# Altium schematic sheet 05 - ETHERNET, USB.SchDoc("05 - ETHERNET, USB")
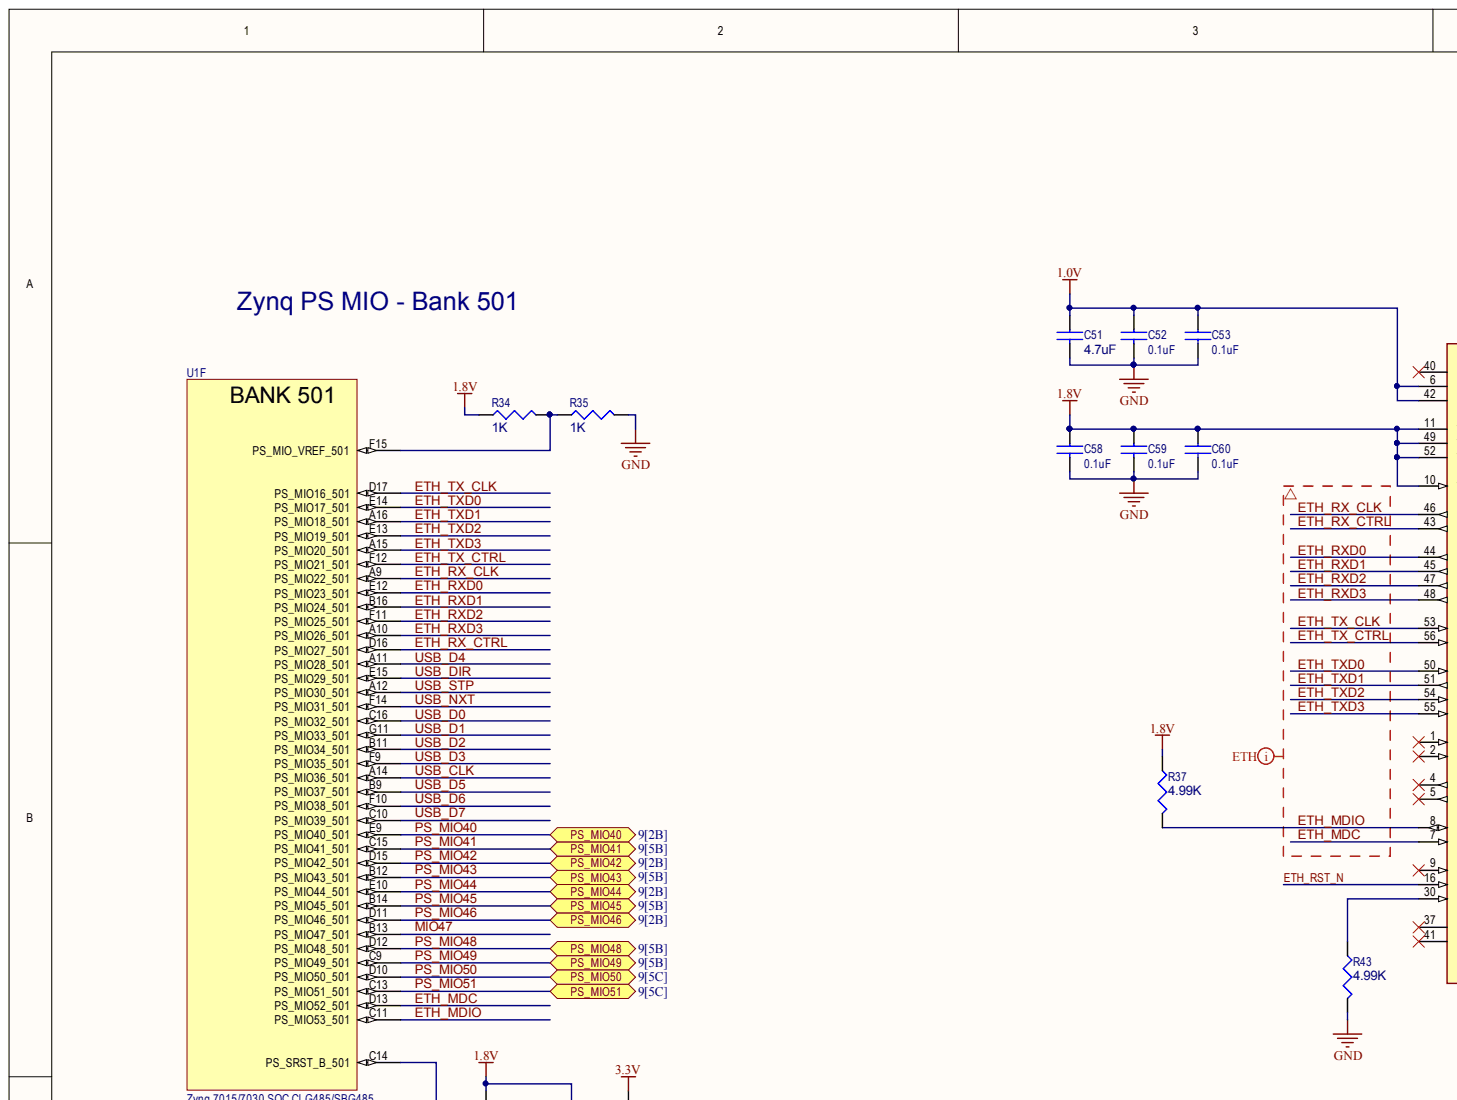
[diagram p0: 05 - ETHERNET, USB.SchDoc, top left]
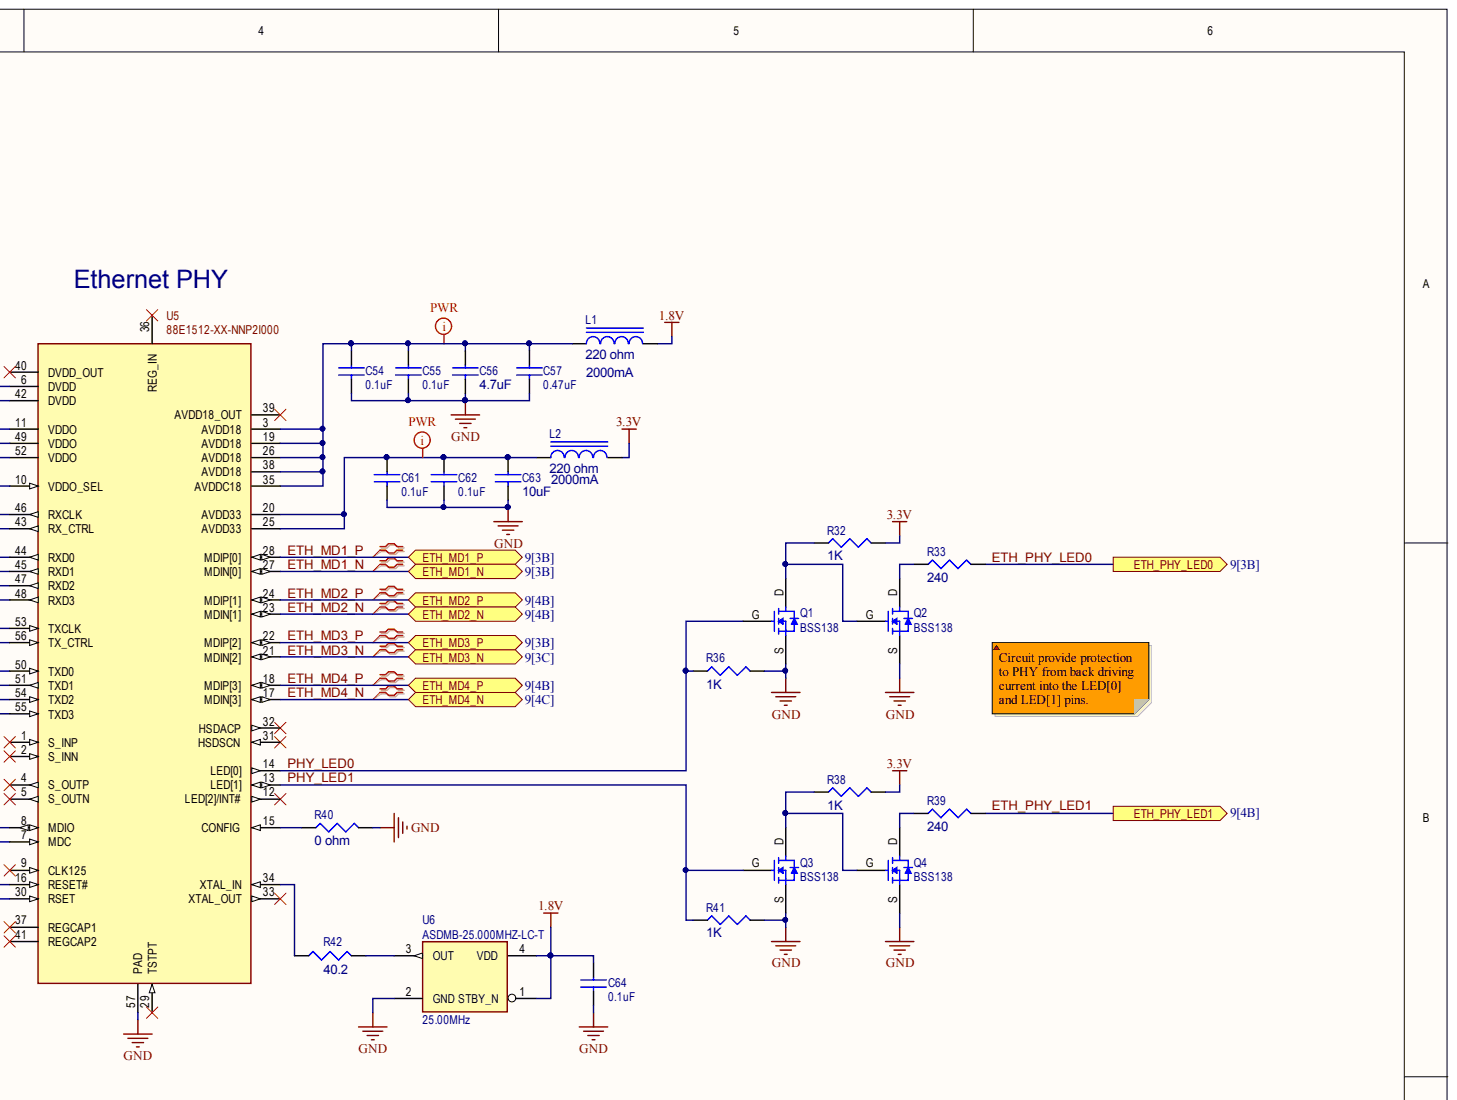
[diagram p1: 05 - ETHERNET, USB.SchDoc, top right]
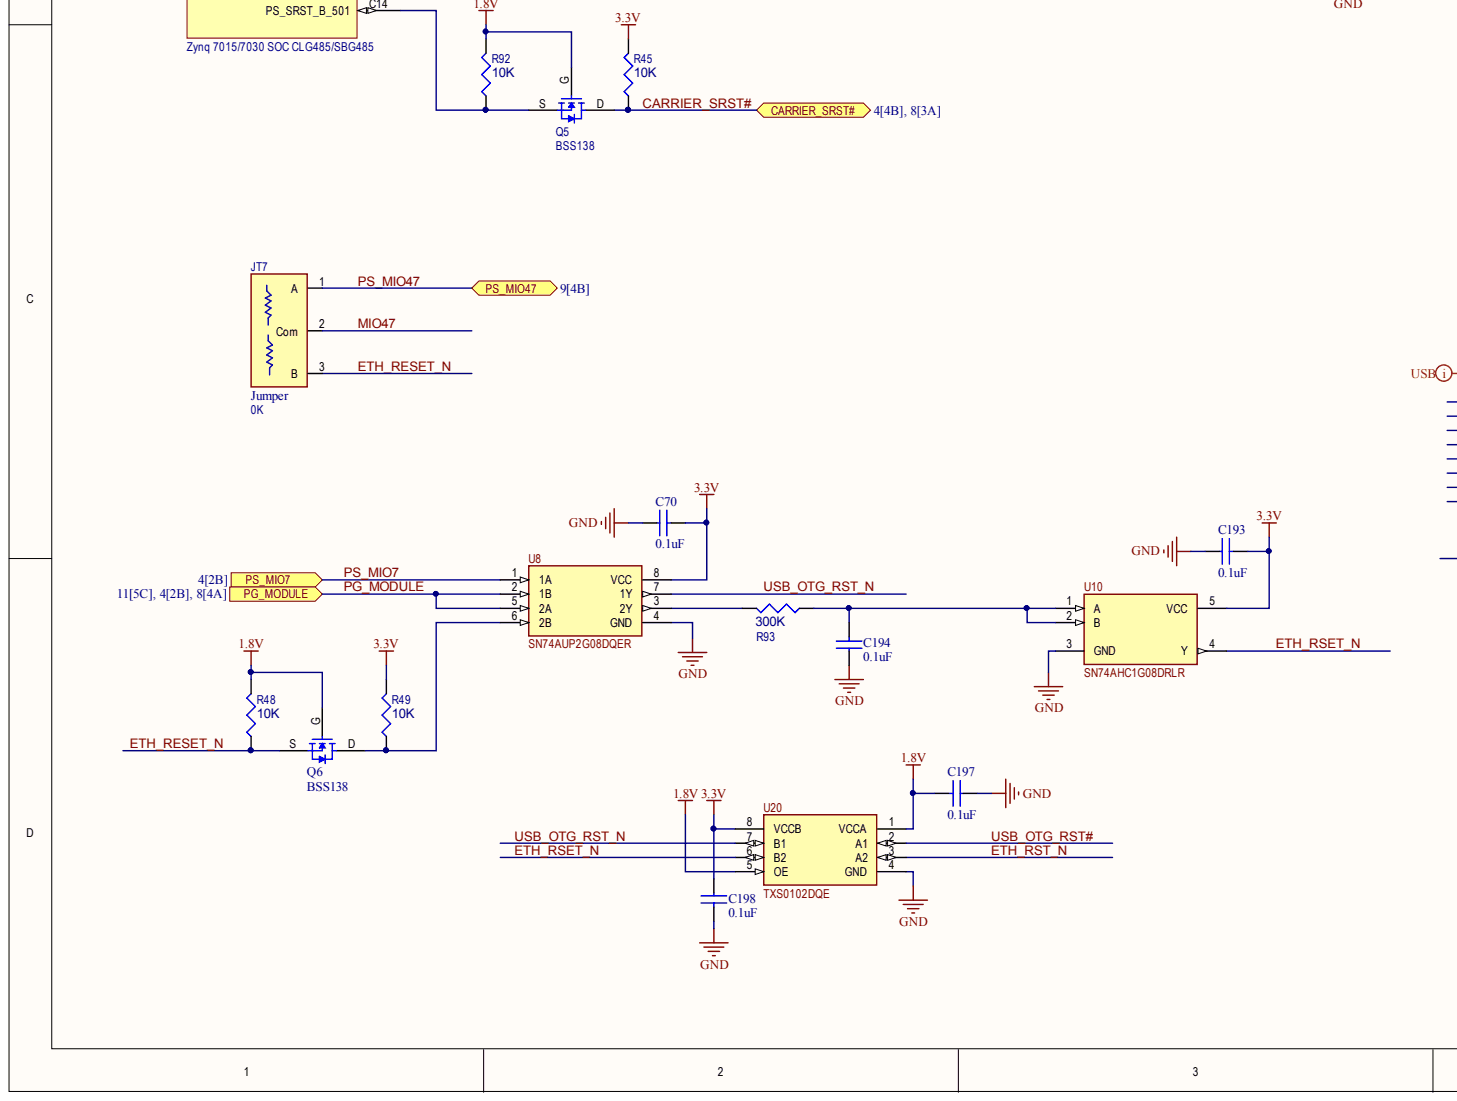
[diagram p2: 05 - ETHERNET, USB.SchDoc, bottom left]
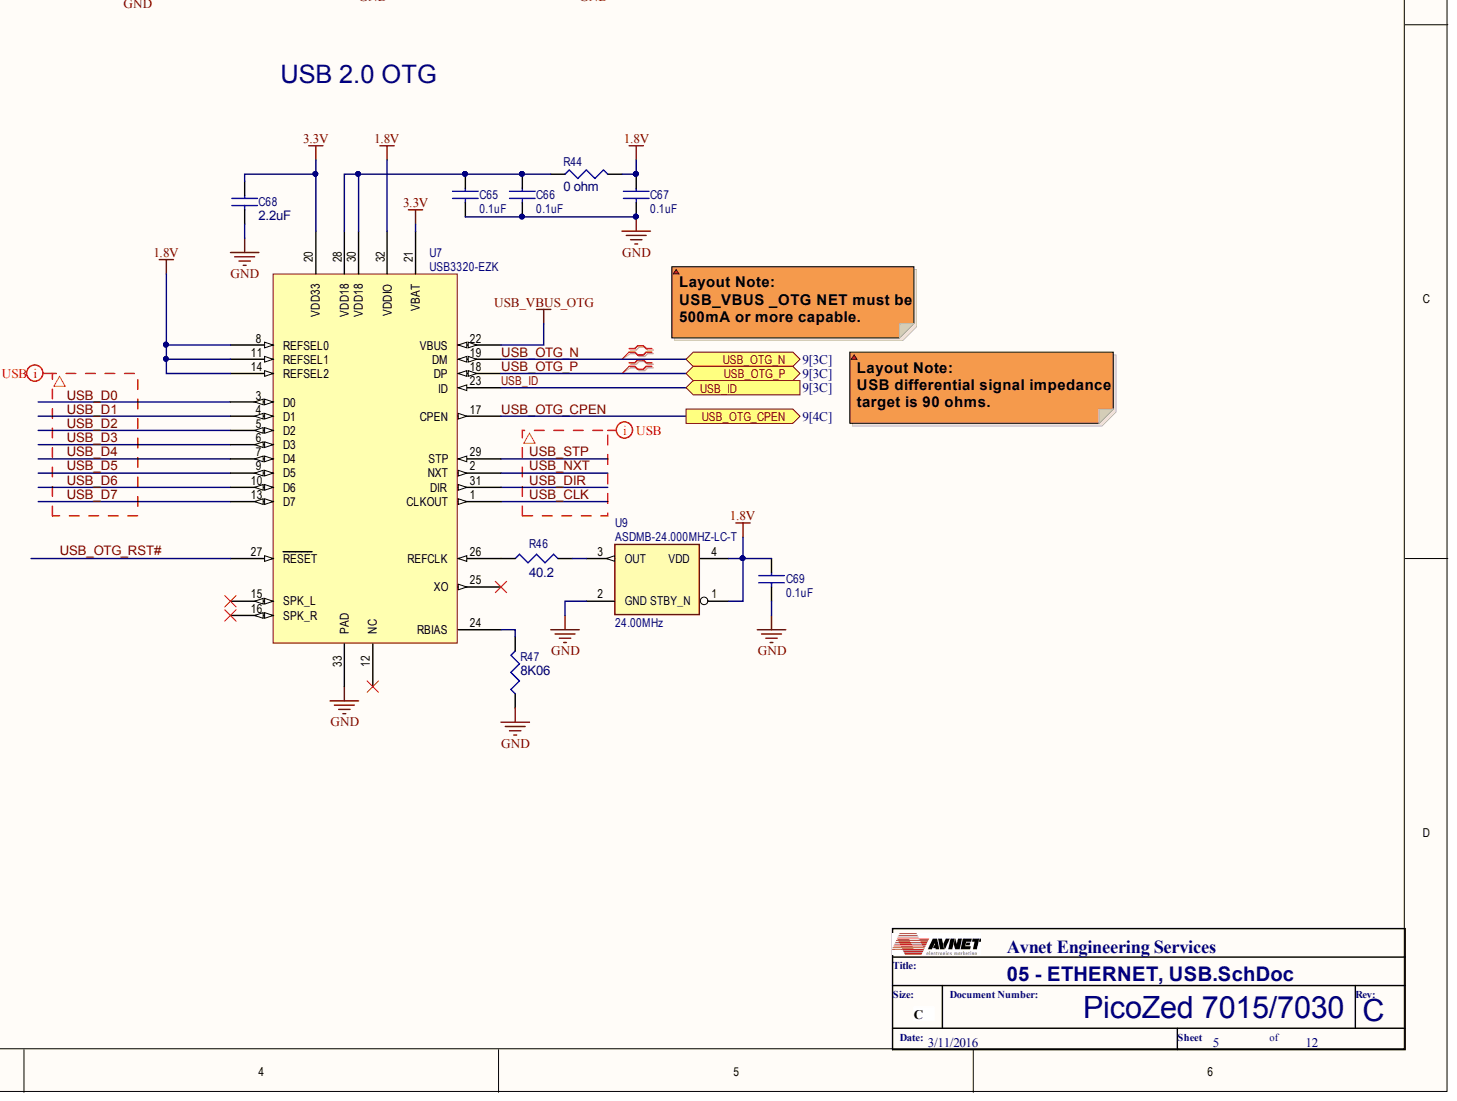
[diagram p3: 05 - ETHERNET, USB.SchDoc, bottom right]

## Parts
C51  4.7uF  [C0402]
C52  value 0.1uF  [C0201]  (hidden comment: PC522)
C53  value 0.1uF  [C0201]  (hidden comment: PC522)
C54  value 0.1uF  [C0201]  (hidden comment: PC522)
C55  value 0.1uF  [C0201]  (hidden comment: PC522)
C56  4.7uF  [C0402]
C57  value 0.47uF  [C0402]  (hidden comment: PC475)
C58  value 0.1uF  [C0201]  (hidden comment: PC522)
C59  value 0.1uF  [C0201]  (hidden comment: PC522)
C60  value 0.1uF  [C0201]  (hidden comment: PC522)
C61  value 0.1uF  [C0201]  (hidden comment: PC522)
C62  value 0.1uF  [C0201]  (hidden comment: PC522)
C63  10uF  [C0603]
C64  value 0.1uF  [C0201]  (hidden comment: PC522)
C65  value 0.1uF  [C0201]  (hidden comment: PC522)
C66  value 0.1uF  [C0201]  (hidden comment: PC522)
C67  value 0.1uF  [C0201]  (hidden comment: PC522)
C68  2.2uF  [C0603]
C69  value 0.1uF  [C0201]  (hidden comment: PC522)
C70  value 0.1uF  [C0201]  (hidden comment: PC522)
C193  value 0.1uF  [C0201]  (hidden comment: PC522)
C194  value 0.1uF  [C0201]  (hidden comment: PC522)
C197  value 0.1uF  [C0201]  (hidden comment: PC522)
C198  value 0.1uF  [C0201]  (hidden comment: PC522)
JT7  value 0K  Device: Jumper  [R0402-DUAL_JMP]
L1  value 220 ohm  Imax: 2000mA  [L0805]  (hidden comment: PF009)
L2  value 220 ohm  Imax: 2000mA  [L0805]  (hidden comment: PF009)
Q1  BSS138  [SOT23GSD]
Q2  BSS138  [SOT23GSD]
Q3  BSS138  [SOT23GSD]
Q4  BSS138  [SOT23GSD]
Q5  BSS138  [SOT23GSD]
Q6  BSS138  [SOT23GSD]
R32  1K  [R0402]
R33  240  [R0402]
R34  1K  [R0402]
R35  1K  [R0402]
R36  1K  [R0402]
R37  4.99K  [R0402]
R38  1K  [R0402]
R39  240  [R0402]
R40  0 ohm  [R0402]
R41  1K  [R0402]
R42  40.2  [R0402]
R43  4.99K  [R0402]
R44  0 ohm  [R0402]
R45  10K  [R0402]
R46  40.2  [R0402]
R47  8K06  [R0402]
R48  10K  [R0402]
R49  10K  [R0402]
R92  10K  [R0402]
R93  300K  [R0402]
U1  Zynq 7015/7030 SOC CLG485/SBG485  [BGA81C80P22X22_1900X1900X244]  (part F)
U5  88E1512-XX-NNP2I000  [QFN56_14X14]
U6  25.00MHz  MFGPN: ASDMB-25.000MHZ-LC-T  [XTL_DSC1001-2.5X2.0]
U7  USB3320-EZK  [QFN32]
U8  SN74AUP2G08DQER  [DQE8]
U9  24.00MHz  MFGPN: ASDMB-24.000MHZ-LC-T  [XTL_DSC1001-2.5X2.0]
U10  SN74AHC1G08DRLR  [SOT553]
U20  TXS0102DQE  [DQE8]

## Ports
CARRIER_SRST#, ETH_MD1_N, ETH_MD1_P, ETH_MD2_N, ETH_MD2_P, ETH_MD3_N, ETH_MD3_P, ETH_MD4_N, ETH_MD4_P, ETH_PHY_LED0, ETH_PHY_LED1, PG_MODULE, PS_MIO7, PS_MIO40, PS_MIO41, PS_MIO42, PS_MIO43, PS_MIO44, PS_MIO45, PS_MIO46, PS_MIO47, PS_MIO48, PS_MIO49, PS_MIO50, PS_MIO51, USB_ID, USB_OTG_CPEN, USB_OTG_N, USB_OTG_P

## Nets
1.0V: C51.1 C52.1 C53.1 U5.6(DVDD) U5.42(DVDD)
1.8V: C58.1 C59.1 C60.1 C64.1 C67.1 C69.1 C197.2 L1.2 Q5.G Q6.G R34.2 R37.1 R44.2 R48.2 R92.2 U5.10(VDDO_SEL) U5.11(VDDO) U5.49(VDDO) U5.52(VDDO) U6.1(STBY_N) U6.4(VDD) U7.8(REFSEL0) U7.11(REFSEL1) U7.14(REFSEL2) U7.32(VDDIO) U9.1(STBY_N) U9.4(VDD) U20.1(VCCA) U20.5(OE)
3.3V: C68.1 C70.2 C193.2 C198.1 L2.2 R32.1 R38.1 R45.2 R49.2 U7.20(VDD33) U7.21(VBAT) U8.8(VCC) U10.5(VCC) U20.8(VCCB)
GND: C51.2 C52.2 C53.2 C54.2 C55.2 C56.2 C57.2 C58.2 C59.2 C60.2 C61.2 C62.2 C63.2 C64.2 C65.2 C66.2 C67.2 C68.2 C69.2 C70.1 C193.1 C194.1 C197.1 C198.2 Q1.S Q2.S Q3.S Q4.S R35.1 R36.1 R40.1 R41.1 R43.2 R47.1 U5.57(PAD) U6.2(GND) U7.33(PAD) U8.4(GND) U9.2(GND) U10.3(GND) U20.4(GND)
USB_VBUS_OTG: U7.22(VBUS)
CARRIER_SRST#: Q5.D R45.1 ; port CARRIER_SRST#
ETH_MD1_N: U5.27(MDIN[0]) ; port ETH_MD1_N
ETH_MD1_P: U5.28(MDIP[0]) ; port ETH_MD1_P
ETH_MD2_N: U5.23(MDIN[1]) ; port ETH_MD2_N
ETH_MD2_P: U5.24(MDIP[1]) ; port ETH_MD2_P
ETH_MD3_N: U5.21(MDIN[2]) ; port ETH_MD3_N
ETH_MD3_P: U5.22(MDIP[2]) ; port ETH_MD3_P
ETH_MD4_N: U5.17(MDIN[3]) ; port ETH_MD4_N
ETH_MD4_P: U5.18(MDIP[3]) ; port ETH_MD4_P
ETH_MDC: U1.D13(PS_MIO52_501) U5.7(MDC)
ETH_MDIO: R37.2 U1.C11(PS_MIO53_501) U5.8(MDIO)
ETH_PHY_LED0: R33.2 ; port ETH_PHY_LED0
ETH_PHY_LED1: R39.2 ; port ETH_PHY_LED1
ETH_RESET_N: JT7.3 Q6.S R48.1
ETH_RSET_N: U10.4(Y) U20.6(B2)
ETH_RST_N: U5.16(RESET#) U20.3(A2)
ETH_RX_CLK: U1.A9(PS_MIO22_501) U5.46(RXCLK)
ETH_RX_CTRL: U1.D16(PS_MIO27_501) U5.43(RX_CTRL)
ETH_RXD0: U1.E12(PS_MIO23_501) U5.44(RXD0)
ETH_RXD1: U1.B16(PS_MIO24_501) U5.45(RXD1)
ETH_RXD2: U1.F11(PS_MIO25_501) U5.47(RXD2)
ETH_RXD3: U1.A10(PS_MIO26_501) U5.48(RXD3)
ETH_TX_CLK: U1.D17(PS_MIO16_501) U5.53(TXCLK)
ETH_TX_CTRL: U1.F12(PS_MIO21_501) U5.56(TX_CTRL)
ETH_TXD0: U1.E14(PS_MIO17_501) U5.50(TXD0)
ETH_TXD1: U1.A16(PS_MIO18_501) U5.51(TXD1)
ETH_TXD2: U1.E13(PS_MIO19_501) U5.54(TXD2)
ETH_TXD3: U1.A15(PS_MIO20_501) U5.55(TXD3)
MIO47: JT7.2 U1.B13(PS_MIO47_501)
PG_MODULE: U8.2(1B) U8.5(2A) ; port PG_MODULE
PHY_LED0: Q1.G R36.2 U5.14(LED[0])
PHY_LED1: Q3.G R41.2 U5.13(LED[1])
PS_MIO7: U8.1(1A) ; port PS_MIO7
PS_MIO40: U1.E9(PS_MIO40_501) ; port PS_MIO40
PS_MIO41: U1.C15(PS_MIO41_501) ; port PS_MIO41
PS_MIO42: U1.D15(PS_MIO42_501) ; port PS_MIO42
PS_MIO43: U1.B12(PS_MIO43_501) ; port PS_MIO43
PS_MIO44: U1.E10(PS_MIO44_501) ; port PS_MIO44
PS_MIO45: U1.B14(PS_MIO45_501) ; port PS_MIO45
PS_MIO46: U1.D11(PS_MIO46_501) ; port PS_MIO46
PS_MIO47: JT7.1 ; port PS_MIO47
PS_MIO48: U1.D12(PS_MIO48_501) ; port PS_MIO48
PS_MIO49: U1.C9(PS_MIO49_501) ; port PS_MIO49
PS_MIO50: U1.D10(PS_MIO50_501) ; port PS_MIO50
PS_MIO51: U1.C13(PS_MIO51_501) ; port PS_MIO51
USB_CLK: U1.A14(PS_MIO36_501) U7.1(CLKOUT)
USB_D0: U1.C16(PS_MIO32_501) U7.3(D0)
USB_D1: U1.G11(PS_MIO33_501) U7.4(D1)
USB_D2: U1.B11(PS_MIO34_501) U7.5(D2)
USB_D3: U1.F9(PS_MIO35_501) U7.6(D3)
USB_D4: U1.A11(PS_MIO28_501) U7.7(D4)
USB_D5: U1.B9(PS_MIO37_501) U7.9(D5)
USB_D6: U1.F10(PS_MIO38_501) U7.10(D6)
USB_D7: U1.C10(PS_MIO39_501) U7.13(D7)
USB_DIR: U1.E15(PS_MIO29_501) U7.31(DIR)
USB_ID: U7.23(ID) ; port USB_ID
USB_NXT: U1.F14(PS_MIO31_501) U7.2(NXT)
USB_OTG_CPEN: U7.17(CPEN) ; port USB_OTG_CPEN
USB_OTG_N: U7.19(DM) ; port USB_OTG_N
USB_OTG_P: U7.18(DP) ; port USB_OTG_P
USB_OTG_RST#: U7.27(RESET) U20.2(A1)
USB_OTG_RST_N: U8.7(1Y) U20.7(B1)
USB_STP: U1.A12(PS_MIO30_501) U7.29(STP)
NetC54_1: C54.1 C55.1 C56.1 C57.1 L1.1 U5.3(AVDD18) U5.19(AVDD18) U5.26(AVDD18) U5.35(AVDDC18) U5.38(AVDD18)
NetC61_1: C61.1 C62.1 C63.1 L2.1 U5.20(AVDD33) U5.25(AVDD33)
NetC65_1: C65.1 C66.1 R44.1 U7.28(VDD18) U7.30(VDD18)
NetC194_2: C194.2 R93.1 U10.1(A) U10.2(B)
NetQ1_D: Q1.D Q2.G R32.2
NetQ2_D: Q2.D R33.1
NetQ3_D: Q3.D Q4.G R38.2
NetQ4_D: Q4.D R39.1
NetQ5_S: Q5.S R92.1 U1.C14(PS_SRST_B_501)
NetQ6_D: Q6.D R49.1 U8.6(2B)
NetR34_1: R34.1 R35.2 U1.F15(PS_MIO_VREF_501)
NetR40_2: R40.2 U5.15(CONFIG)
NetR42_1: R42.1 U5.34(XTAL_IN)
NetR42_2: R42.2 U6.3(OUT)
NetR43_1: R43.1 U5.30(RSET)
NetR46_1: R46.1 U7.26(REFCLK)
NetR46_2: R46.2 U9.3(OUT)
NetR47_2: R47.2 U7.24(RBIAS)
NetR93_2: R93.2 U8.3(2Y)
N00242:
N00243:
N00244:

Not connected: U5 1(S_INP) 2(S_INN) 4(S_OUTP) 5(S_OUTN) 9(CLK125) 12(LED[2]/INT#) 29(TSTPT) 31(HSDSCN) 32(HSDACP) 33(XTAL_OUT) 36(REG_IN) 37(REGCAP1) 39(AVDD18_OUT) 40(DVDD_OUT) 41(REGCAP2); U7 12(NC) 15(SPK_L) 16(SPK_R) 25(XO)

## Library data of the parts
C51 C56 = AVPC-0000499: CAP SM 4.7uF 6.3V 20% X5R C0402; PartCode: PC; AsyTxt: PC499; Database Table Name: Passive-Cap$; MFG: Murata; MFG Code: AVX; MFGPN: GRM155R60J475ME87D; Power: 0; Temp: I; Availability: 140K-DK; AVLNo: AVPC-0000499; CLASSNAME: U11_BYP; Component Kind: Standard; ComponentLink1Description: Datasheet; Cost: .46; Device: CAP; Dielectric: X5R; PkgType: SM; Received: 0; RoHS: Yes; Size: 1.9; Status: Preliminary; Tol: 20%; Value: 4.7uF; Volt: 6.3V; ComponentLink1URL: C:\AVDBLIB\DATASHEETS\murata_caps_c02e.pdf; Library Name: Avnet_DBlib.DbLib
C52-C55 C58-C62 C64-C67 C69 = AVPC-0000522: CAP SM 0.1uF 16V 10% X5R C0201; PartCode: PC; Database Table Name: Passive-Cap$; MFG: TDK; MFGPN: C0603X5R1C104K030BC; Temp: I; AsyTxt: PC522; Component Kind: Standard; ComponentLink1Description: Datasheet; Device: CAP; Dielectric: X5R; MFG Code: TDK; PkgType: SM; RoHS: Yes; Status: Preliminary; Tol: 10%; Volt: 16V; Library Name: Avnet_DBlib.DbLib
  C52-C55 C58-C62: CLASSNAME: U11_BYP
C57 = AVPC-0000475: CAP SM 0.47uF 6.3V 10% X5R C0402; PartCode: PC; AVLNo: AVPC-0000529; Database Table Name: Passive-Cap$; MFG: Taiyo Yuden; MFG Code: TAI; MFGPN: JMK105BJ474KV-F; Temp: I; AsyTxt: PC475; CLASSNAME: U11_BYP; Component Kind: Standard; ComponentLink1Description: Datasheet; ComponentLink2Description: MFR Website; Device: CAP; Dielectric: X5R; ESR: CAP; PkgType: SM; RoHS: Yes; Status: Preliminary; Tol: 10%; Volt: 6.3V; ComponentLink1URL: C:\AVDBLIB\DATASHEETS\; Library Name: Avnet_DBlib.DbLib
C63 = AVPC-0000086: CAP SM 10uF 6.3V 20% X5R C0603; PartCode: PC; AsyTxt: PC086; Database Table Name: Passive-Cap$; MFG: AVX; MFGPN: 06036D106MAT2A; Temp: I; AVLNo: AVPC-0000086; CLASSNAME: U11_BYP; Component Kind: Standard; ComponentLink1Description: Datasheet; Device: CAP; Dielectric: X5R; ESR: CAP; MFG Code: AVX; PkgType: SM; RoHS: Yes; Status: Preliminary; Tol: 20%; Value: 10uF; Volt: 6.3V; ComponentLink1URL: C:\AVDBLIB\DATASHEETS\AVX_CCOG.pdf; Library Name: Avnet_DBlib.DbLib
C68 = AVPC-0000155: CAP SM 2.2uF 6.3V 10% X5R C0603; PartCode: PC; AsyTxt: PC155; Database Table Name: Passive-Cap$; MFG: AVX; MFGPN: 06036D225KAT2A; Power: 0; Temp: I; Availability: 4K-AV; AVLNo: AVPC-0000155; Component Kind: Standard; ComponentLink1Description: Datasheet; ComponentLink2Description: MFR Website; ComponentLink2URL: www.avx.com; Cost: 0.04; Device: CAP; Dielectric: X5R; MFG Code: AVX; PkgType: SM; Received: 0; RoHS: Yes; Size: 3.4; Status: Preliminary; Tol: 10%; Value: 2.2uF; Volt: 6.3V; ComponentLink1URL: C:\AVDBLIB\DATASHEETS\AVX_CX5R.pdf; Library Name: Avnet_DBlib.DbLib
C70 C194 = AVPC-0000522: CAP SM 0.1uF 10V 10% X5R C0201; PartCode: PC; Database Table Name: Passive-Cap$; MFG: AVX; MFGPN: 02016D104KAT2A; Temp: I; AsyTxt: PC522; AVLNo: AVPC-0000522; Component Kind: Standard; ComponentLink1Description: Datasheet; Device: CAP; Dielectric: X5R; PkgType: SM; RoHS: Yes; Status: Preliminary; Tol: 10%; Volt: 10V; ComponentLink1URL: C:\AVDBLIB\DATASHEETS\AVX_CCOG.pdf; Library Name: Avnet_DBlib.DbLib
C193 C197 C198 = AVPC-0000522: CAP SM 0.1uF 10V 10% X5R C0201; PartCode: PC; Database Table Name: Passive-Cap$; MFG Code: AVX; MFGPN: 02016D104KAT2A; AsyTxt: PC522; AVLNo: AVPC-0000522; Component Kind: Standard; ComponentLink1Description: Datasheet; Device: CAP; Dielectric: X5R; PkgType: SM; RoHS: Yes; Status: Preliminary; Tol: 10%; Volt: 10V; ComponentLink1URL: C:\AVDBLIB\DATASHEETS\AVX_CCOG.pdf; Library Name: Avnet_DBlib.DbLib
JT7 = AVPO-0000025: Jumper 3-pad 0402 RES - 0 Ohm : Pads 1-2; PartCode: PO; Database Table Name: Passive-Other$; Device Type: 3-pad 0R0 0402; MFG: Vishay; MFGPN: CRCW04020000Z0ED; Note: Place Pads: 1-2; PkgType: SM; Temp: I; Component Kind: Standard; ComponentLink1Description: Datasheet; Part #: CRCW04020000Z0ED; RoHS: Yes; Status: Preliminary; ComponentLink1URL: C:\AVDBLIB\DATASHEETS\Dale_CRCW.pd; Library Name: Avnet_DBlib.DbLib
L1 L2 = AVPF-0000009: FB SM 220 ohm@100MHz 2000mA 0.25 0.045 ohm@DC L0805; PartCode: PF; Database Table Name: Passive-Ferrite Bead$; MFG: Murata; MFG Code: MUR; MFGPN: BLM21PG221SN1D; Temp: C; Test Freq: 100MHz; Tol: 0.25; AsyTxt: PF009; AVLNo: AVPF-0000009; CLASSNAME: U11_BYP; Component Kind: Standard; ComponentLink1Description: Datasheet; DCR: 0.045 ohm; Device: FB; PkgType: SM; RoHS: Yes; Status: Preliminary; ComponentLink1URL: C:\AVDBLIB\DATASHEETS\L0110S0100BLM21P.pdf; Library Name: Avnet_DBlib.DbLib
Q1-Q5 = MOSFET-N: MFG: On Semi; MFGPN: BSS138LT1G; Temp: I; Component Kind: Standard; Description: MOSFET-N; Library Name: HKADS_Lib.IntLib
Q6 = MOSFET-N: MFG#1/Part#: OnSemi: BSS138LT1G; MFG Code: ON SEMI; MFGPN: BSS138LT1G; Component Kind: Standard; Description: MOSFET-N; MFG Code: ON Semi; Library Name: HKADS_Lib.IntLib
R32 R34-R36 R38 R41 = AVPR-0000052: RES SM 1K 62mW 0.01 R0402; PartCode: PR; AsyTxt: PR052; Database Table Name: Passive-Res$; MFG: Vishay; MFG Code: DAL; MFGPN: CRCW04021K00FKED; Temp: I; Tol: 0.01; AVLNo: AVPR-0000052; Component Kind: Standard; ComponentLink1Description: Datasheet; Device: RES; PkgType: SM; RoHS: Yes; Status: Preliminary; Value: 1K; Watt: 62mW; ComponentLink1URL: C:\AVDBLIB\DATASHEETS\Dale_CRCW.pd; Library Name: Avnet_DBlib.DbLib
R33 R39 = AVPR-0000511: RES SM 240 62mW 0.01 R0402; Alt1MFR: NIC; PartCode: PR; Alt1PN: NRC04F2400TRF; AsyTxt: PR511; Database Table Name: Passive-Res$; MFG: Vishay; MFG Code: DAL; MFGPN: CRCW0402240RFKED; Power: 0; Temp: I; Tol: 0.01; Availability: 1M-AV; AVLNo: AVPR-0000511; Component Kind: Standard; ComponentLink1Description: Datasheet; Cost: .01; Device: RES; PkgType: SM; Received: 0; RoHS: Yes; Size: 1.9; Status: Preliminary; Value: 240; Watt: 62mW; ComponentLink1URL: C:\AVDBLIB\DATASHEETS\Dale_CRCW.pd; Library Name: Avnet_DBlib.DbLib
R37 R43 = AVPR-0000094: RES SM 4.99K 62mW 0.01 R0402; PartCode: PR; AsyTxt: PR094; Database Table Name: Passive-Res$; MFG: Vishay; MFG Code: DAL; MFGPN: CRCW04024K99FKED; Temp: I; Tol: 0.01; AVLNo: AVPR-0000094; Component Kind: Standard; ComponentLink1Description: Datasheet; Device: RES; PkgType: SM; RoHS: Yes; Status: Preliminary; Value: 4.99K; Watt: 62mW; ComponentLink1URL: C:\AVDBLIB\DATASHEETS\Dale_CRCW.pd; Library Name: Avnet_DBlib.DbLib
R40 R44 = AVPR-0000027: RES SM 0 ohm 62mW J R0402; PartCode: PR; AsyTxt: PR027; Database Table Name: Passive-Res$; MFG: Vishay; MFG Code: DAL; MFGPN: CRCW04020000Z0ED; Temp: I; AVLNo: AVPR-0000027; Component Kind: Standard; ComponentLink1Description: Datasheet; Device: RES; PkgType: SM; RoHS: Yes; Status: Preliminary; Value: 0 ohm; Watt: 62mW; ComponentLink1URL: C:\AVDBLIB\DATASHEETS\Dale_CRCW.pd; Library Name: Avnet_DBlib.DbLib
R42 R46 = (no symbol name): RES SM 40.2 62mW 0.01 R0402; PartCode: PR; AsyTxt: PR092; MFG: Vishay; MFG Code: DAL; MFGPN: CRCW040240R2FKED; Power: 0; Temp: I; Tol: 0.01; Watt: 63mW; Availability: 2M-AV; CLASSNAME: DDR_TERMR; Component Kind: Standard; ComponentLink1Description: Datasheet; Cost: .01; Device: RES; Library Name: Z7045_MM.SchLib; PkgType: SM; Received: 0; RoHS: Yes; Size: 1.9; Status: Preliminary; Value: 40.2; Watt: 62mW; ComponentLink1URL: C:\AVDBLIB\DATASHEETS\Dale_CRCW.pd
R45 R92 = AVPR-0000034: RES SM 10K 62mW 0.01 R0402; PartCode: PR; AsyTxt: PR034; Database Table Name: Passive-Res$; MFG: Vishay; MFG Code: DAL; MFGPN: CRCW040210K0FKED; Power: 0; Temp: I; Tol: 0.01; Watt: 63mW; Availability: 2M-AV; AVLNo: AVPR-0000034; CLASSNAME: DDR_TERM; Component Kind: Standard; ComponentLink1Description: Datasheet; Cost: .01; Device: RES; PkgType: SM; Received: 0; RoHS: Yes; Size: 1.9; Status: Preliminary; Value: 10K; Watt: 62mW; ComponentLink1URL: C:\AVDBLIB\DATASHEETS\Dale_CRCW.pd; Library Name: Avnet_DBlib.DbLib
R47 = (no symbol name): RES SM 8.06k 62mW 0.01 R0402; PartCode: PR; AsyTxt: PR092; AVLNo: AVPR-0000561; MFG: Vishay; MFG Code: DAL; MFGPN: CRCW04028K06FKED; Power: 0; Temp: I; Tol: 0.01; Watt: 63mW; Availability: 2M-AV; Component Kind: Standard; ComponentLink1Description: Datasheet; Cost: .01; Device: RES; Library Name: Z7045_MM.SchLib; PkgType: SM; Received: 0; RoHS: Yes; Size: 1.9; Status: Preliminary; Value: 8K06; Watt: 62mW; ComponentLink1URL: C:\AVDBLIB\DATASHEETS\Dale_CRCW.pd
R48 R49 = AVPR-0000034: RES SM 10.0K 62mW 0.01 R0402; PartCode: PR; AsyTxt: PR034; Database Table Name: Passive-Res$; MFG Code: DAL; MFGPN: CRCW040210K0FKED; Power: 0; Tol: 0.01; Watt: 63mW; Availability: 2M-AV; AVLNo: AVPR-0000034; CLASSNAME: DDR_TERM; Component Kind: Standard; ComponentLink1Description: Datasheet; Cost: .01; Device: RES; PkgType: SM; Received: 0; RoHS: Yes; Size: 1.9; Status: Preliminary; Value: 10K; Watt: 62mW; ComponentLink1URL: C:\AVDBLIB\DATASHEETS\Dale_CRCW.pd; Library Name: Avnet_DBlib.DbLib
R93 = AVPR-0000034: RES SM 300.0K 62mW 0.01 R0402; Database Table Name: Passive-Res$; MFG: Vishay; MFGPN: CRCW0402300KFKED; Temp: I; Watt: 63mW; Component Kind: Standard; Device: RES; Value: 300K; Library Name: Avnet_DBlib.DbLib
U1 = Zynq 7015/7030 SOC SBG485: MFG: Xilinx; MFGPN: XC7Z030-1SBG485-C; Temp: C; CLASSNAME: BGA; Component Kind: Standard; Description: Zynq 7015/7030 SOC SBG485; Library Name: PicoZed+_Rev_A.SCHLIB
U5 = 88E1512: High Integrated 10/100/1000 Ultra Gigabit Ethernet Tranceiver; AVLNo: AVIY-0000047; MFG: Marvell; MFGPN: 88E1512-A0-NNP2C000; Temp: C; Component Kind: Standard; Library Name: Zynq_Z010_ZedStick.SchLib
U6 = DSC1001DI1-025.0000: OSC, SM, Discera,1.8V, 25.0000MHz, 50ppm, 2.5x2.0mm; AVLNo: AVIT-0000121; MFG: Abracon; Temp: C; Component Kind: Standard; Library Name: Zynq_Z010_ZedStick.SchLib
U7 = USB3320C-EZK: USB 2.0 ULPI OTG Tranceiver; AVLNo: AVIY-0000045; MFG: Microchip; Temp: C; Component Kind: Standard; Library Name: Z7045_MM.SchLib; MFGPN: USB3320C-EZK
U8 = (no symbol name): Low Power Dual 2-Input Positive-AND Gate; MFG: Texas Instruments; MFG Code: TIS; Temp: C; Component Kind: Standard; Library Name: new.SchLib; MFGPN: SN74AUP2G08DQER; RoHS: Yes; Status: Preliminary
U9 = DSC1001DI1-024.0000: OSC, SM, Discera, 1.8V, 24.0000MHz, 50ppm, 2.5x2.0mm; AVLNo: AVIT-0000120; MFG: Abracon; Temp: C; Component Kind: Standard; Library Name: Zynq_Z010_ZedStick.SchLib
U10 = (no symbol name): Low Power Single 2-Input Positive-AND Gate; MFG: Texas Instruments; Temp: I; Component Kind: Standard; Library Name: new.SchLib; MFGPN: SN74AHC1G08DRLR; RoHS: Yes; Status: Preliminary
U20 = TXS0102: 2-Bit Bidirectional Voltage-Level Translator; PartCode: ID; AsyTxt: ID486; AVLNo: AVID-0000486; Bits: 2bit; MFG: Texas Instruments; PkgType: SM; Ports/Channels: 2ch Bidir; Temp: I; CLASSNAME: FINEPITCH; Component Kind: Standard; Device: TXS0102; Device Type: TRANSLATOR; Library Name: new.SchLib; MFG Code: Texas Instruments; MFGPN: TXS0102DQE; RoHS: Yes; Status: Preliminary; Technology: LVCMOS
